# Altium schematic sheet power.SchDoc
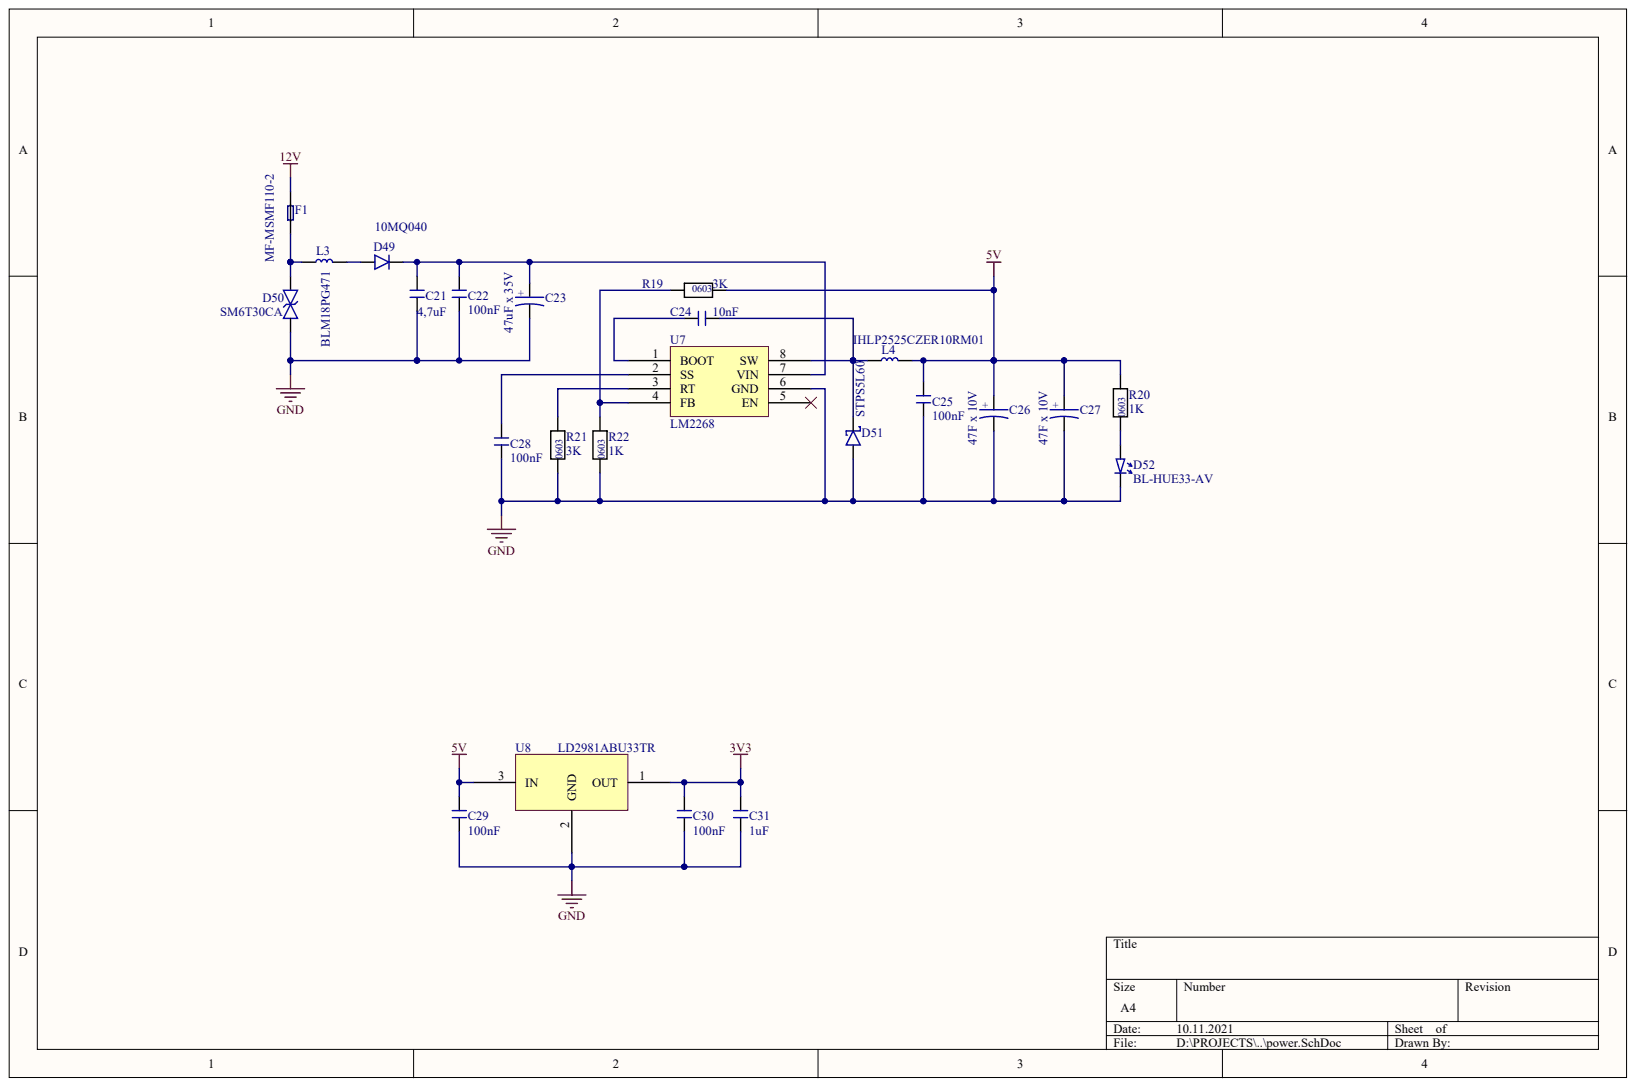
[diagram p0: power.SchDoc]

## Parts
C21  4,7uF
C22  100nF
C23  47uF x 35V
C24  10nF
C25  100nF
C26  47F x 10V
C27  47F x 10V
C28  100nF
C29  100nF
C30  100nF
C31  1uF
D49  10MQ040
D50  SM6T30CA
D51  STPS5L60
D52  BL-HUE33-AV
F1  MF-MSMF110-2
L3  BLM18PG471
L4  IHLP2525CZER10RM01
R19  3K
R20  1K
R21  3K
R22  1K
U7  LM2268
U8  LD2981ABU33TR

## Nets
3V3: C30.2 C31.2 U8.1
5V: C25.1 C26.1 C27.1 C29.2 L4.2 R19.1 R20.2 U8.3
12V: F1.1
GND: C21.1 C22.2 C23.2 C25.2 C26.2 C27.2 C28.2 C29.1 C30.1 C31.1 D50.2 D51.1 D52.2 R21.1 R22.1 U7.6(GND) U8.2
NetC21_2: C21.2 C22.1 C23.1 D49.2 U7.7(VIN)
NetC24_1: C24.1 D51.2 L4.1 U7.8(SW)
NetC24_2: C24.2 U7.1(BOOT)
NetC28_1: C28.1 U7.2(SS)
NetD49_1: D49.1 L3.2
NetD50_1: D50.1 F1.2 L3.1
NetD52_1: D52.1 R20.1
NetR19_2: R19.2 R22.2 U7.4(FB)
NetR21_2: R21.2 U7.3(RT)

Not connected: U7 5(EN)
